# Altium schematic sheet Power_regulator.SchDoc(Power_regulator)
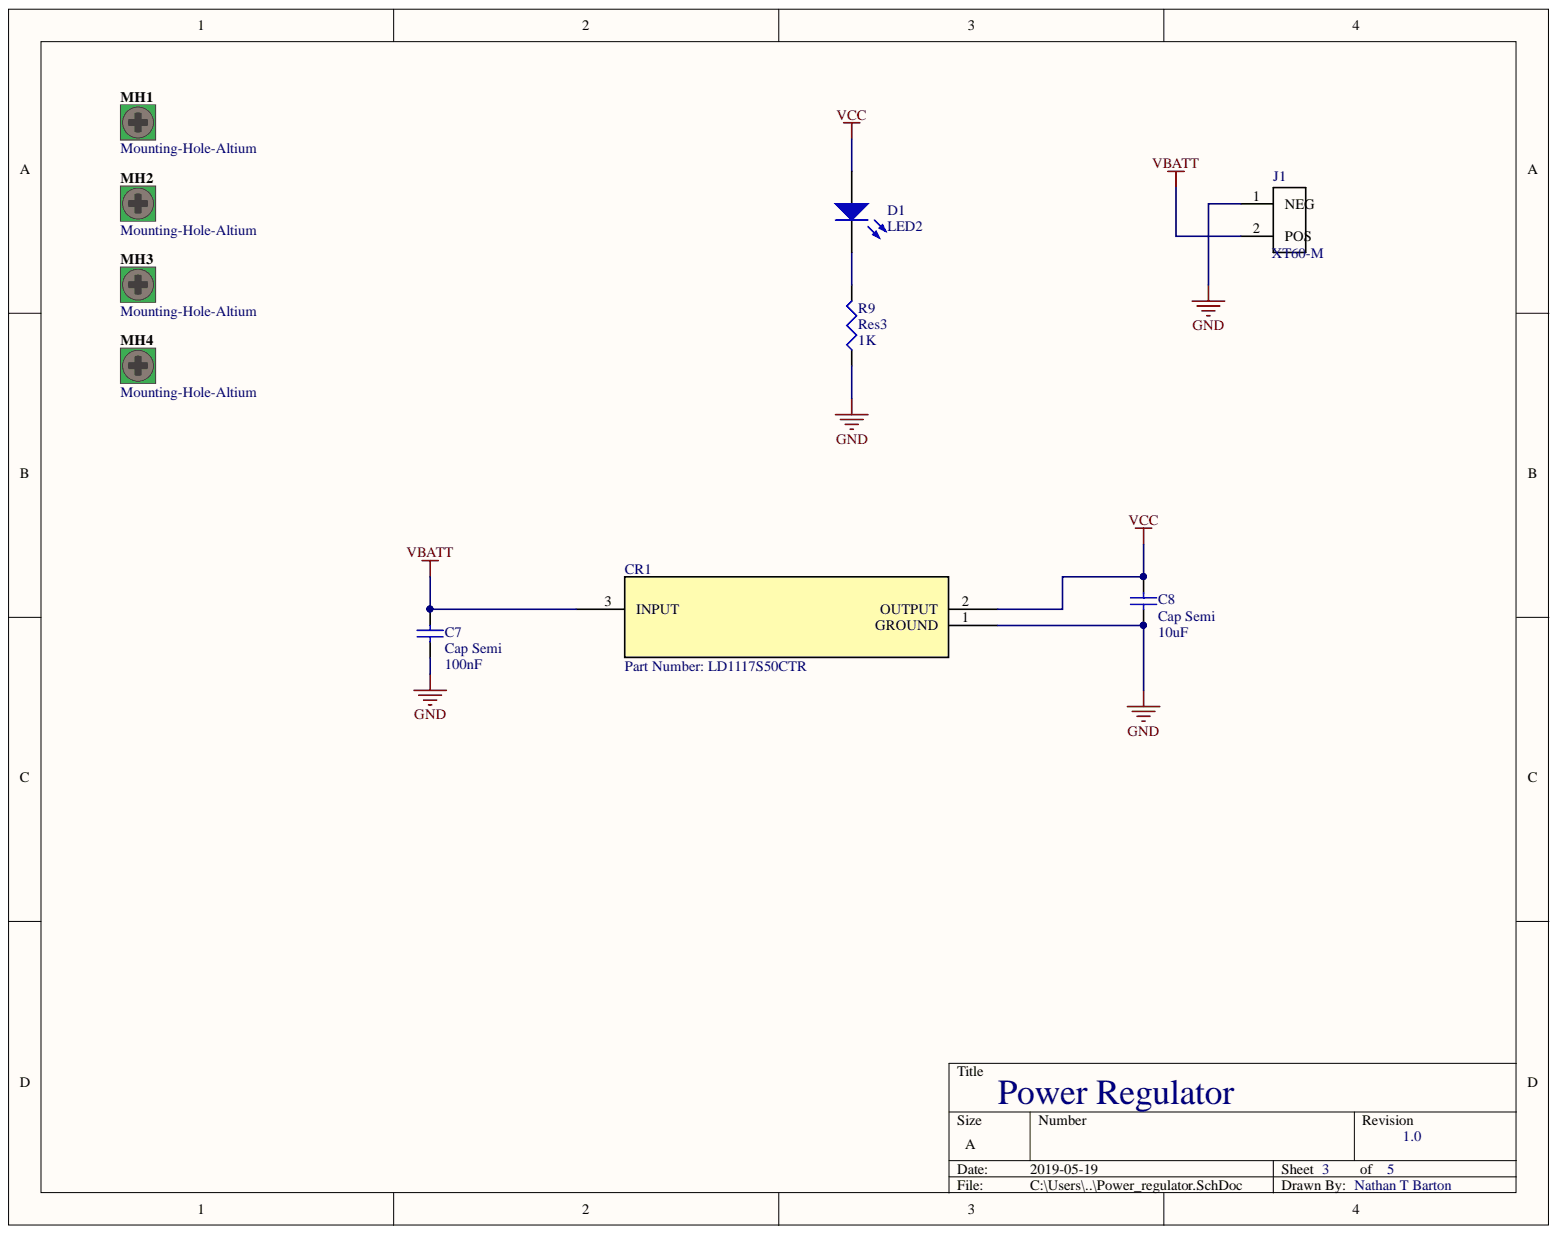
[diagram p0: Power_regulator.SchDoc]

## Parts
C7  Cap Semi  value 100nF  [C1206]
C8  Cap Semi  value 10uF  [C1206]
CR1  Part Number: LD1117S50CTR  [SOT-223]
D1  LED2  [3.2X1.6X1.1]
J1  XT60-M  [*AMASS_XT60-M]
MH1  Mounting-Hole-Altium  [Mounting-Hole-Altium]  (no BOM)
MH2  Mounting-Hole-Altium  [Mounting-Hole-Altium]  (no BOM)
MH3  Mounting-Hole-Altium  [Mounting-Hole-Altium]  (no BOM)
MH4  Mounting-Hole-Altium  [Mounting-Hole-Altium]  (no BOM)
R9  Res3  value 1K  [J1-0603]

## Nets
GND: C7.1 C8.1 CR1.1 J1.1 R9.1
VBATT: C7.2 CR1.3 J1.2
VCC: C8.2 CR1.2 D1.1
NetD1_2: D1.2 R9.2

## Library data of the parts
C7 C8 = Cap Semi: Capacitor (Semiconductor SIM Model); Simulation: CAP; Signal Integrity: Cap Semi; Published: 8-Jun-2000; PackageReference: C1206; PackageDescription: Chip Capacitor; Library Name: Miscellaneous Devices.IntLib; LatestRevisionNote: IPC-7351 Footprint Added.; LatestRevisionDate: 29-May-2009; Component Kind: Standard; Publisher: Altium Limited
CR1 = LD1117S50CTR: No Description Available; Vendor: STMicroelectronics; Type: DEV; Built_By: EMA_UL_Team; Value: Value; RefDes: RefDes; Manufacturer_Part_Number: LD1117S50CTR; Component Kind: Standard; Library Name: spoolbot_library.IntLib; Copyright: Copyright (C) 2018 Accelerated Designs. All rights reserved
D1 = LED2: Typical RED, GREEN, YELLOW, AMBER GaAs LED; Simulation: LED2; Published: 8-Jun-2000; PackageVersion: Feb-2002; PackageReference: 3.2X1.6X1.1; PackageDescription: SM LED; 2 Flat Leads; Library Name: Miscellaneous Devices.IntLib; LatestRevisionNote: Re-released for DXP Platform.; LatestRevisionDate: 17-Jul-2002; Component Kind: Standard; Publisher: Altium Limited
J1 = XT60-M: MF: AMASS; SnapEDA_Link: https://www.snapeda.com/parts/XT60-M/AMASS/view-part/?ref=snap; Price: None; Package: Package Analog Devices; MP: XT60-M; Component Kind: Standard; Availability: Unavailable; Library Name: spoolbot_library.IntLib
MH1-MH4 = CMP-0001-00027-1: Mounting Hole, Altium, 3.8mm pad, 3.4mm plated hole; Library Name: Altium Content Vault; ClassName: Mounting Hole; Publisher: Altium
R9 = Res3: Resistor; Simulation: RESISTOR; Signal Integrity: Res; Published: 8-Jun-2000; PackageReference: J1-0603; PackageDescription: Chip Resistor; Library Name: Miscellaneous Devices.IntLib; LatestRevisionNote: IPC-7351 Footprint Added.; LatestRevisionDate: 29-May-2009; Component Kind: Standard; Publisher: Altium Limited
